# Altium schematic sheet Sheet1.SchDoc
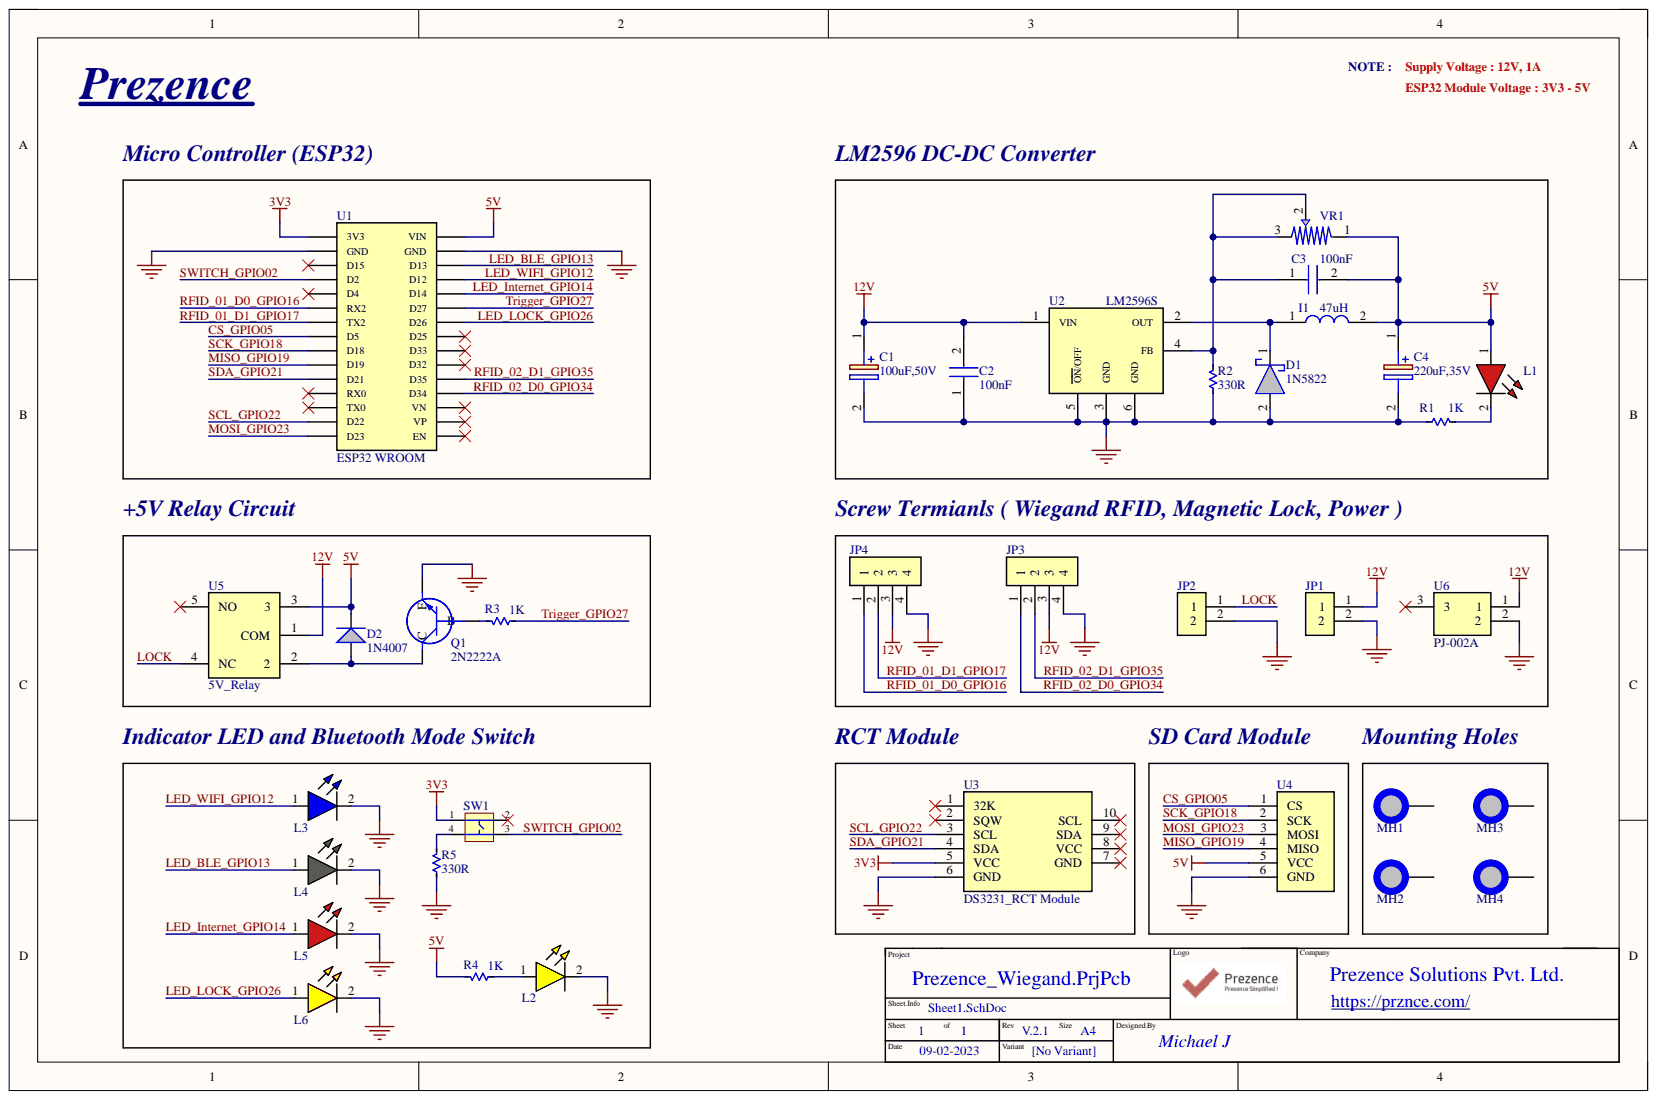
[diagram p0: Sheet1.SchDoc]

## Parts
C1  100uF,50V  [100uF,50V]
C2  100nF  [C_5.08mm]
C3  100nF  [C_5.08mm]
C4  220uF,35V  [220uF,35V]
D1  1N5822  [1N5822_(Short)]
D2  1N4007  [1N4007]
I1  47uH  [47uH_Through_Hole]
JP1  Pluggable, Receptacle, Horizontal, 5.08 Pitch, Terminal Block Connector  [2_Pin_Pluggable_Terminal]  (value not drawn)
JP2  Pluggable, Receptacle, Horizontal, 5.08 Pitch, Terminal Block Connector  [2_Pin_Pluggable_Terminal]  (value not drawn)
JP3  Screw_Terminal  [4_Pin_Pluggable_Terminal]  (value not drawn)
JP4  Screw_Terminal  [4_Pin_Pluggable_Terminal]  (value not drawn)
L1  LED  [LED_RED]  (value not drawn)
L2  LED  [LED_Yellow]  (value not drawn)
L3  LED  [LED_Blue]
L4  LED  [LED_Green]
L5  LED  [LED_RED]
L6  LED  [LED_Orange]
MH1  Mounting_Holes_3.2mm  [Mounting_Hole_3.2mm]  (value not drawn)
MH2  Mounting_Holes_3.2mm  [Mounting_Hole_3.2mm]  (value not drawn)
MH3  Mounting_Holes_3.2mm  [Mounting_Hole_3.2mm]  (value not drawn)
MH4  Mounting_Holes_3.2mm  [Mounting_Hole_3.2mm]  (value not drawn)
Q1  2N2222A  [2N2222A_(TO-92)]
R1  1K  [Resistor]
R2  330R  [Resistor]
R3  1K  [Resistor]
R4  1K  [Resistor]
R5  330R  [Resistor]
SW1  switch 12mm  [Switch_12mm]  (value not drawn)
U1  ESP32 WROOM  [ESP32_(Through Hole)]
U2  LM2596S  [LM2596]
U3  DS3231_RCT Module  [DS3231_RCT_MODULE]
U4  SD_Card_Module  [SD_Card_Module]
U5  5V_Relay  [SRD_05VDC_SL_C]
U6  PJ-002A  [PJ002A]
VR1  3296W-1-103  [3296W-1-103]  (value not drawn)

## Nets
3V3: SW1.1 U1.1(3V3) U3.5(VCC)
5V: C3.2 C4.1 D2.1 I1.2 L1.1 R4.2 U1.30(VIN) U4.5(VCC) U5.3 VR1.1
12V: C1.1 C2.2 JP1.1 JP3.3 JP4.3 U2.1(VIN) U5.1(COM) U6.1
GND: C1.2 C2.1 C4.2 D1.2 JP1.2 JP2.2 JP3.4 JP4.4 L2.2 L3.2 L4.2 L5.2 L6.2 Q1.1 R1.1 R2.1 R5.1 U1.2(GND) U1.29(GND) U2.3(GND) U2.5(ON/OFF) U2.6(GND) U3.6(GND) U4.6(GND) U6.2
CS_GPIO05: U1.8(D5) U4.1(CS)
LED_BLE_GPIO13: L4.1 U1.28(D13)
LED_Internet_GPIO14: L5.1 U1.26(D14)
LED_LOCK_GPIO26: L6.1 U1.24(D26)
LED_WIFI_GPIO12: L3.1 U1.27(D12)
LOCK: JP2.1 U5.4(NC)
MISO_GPIO19: U1.10(D19) U4.4(MISO)
MOSI_GPIO23: U1.15(D23) U4.3(MOSI)
RFID_01_D0_GPIO16: JP4.1 U1.6(RX2)
RFID_01_D1_GPIO17: JP4.2 U1.7(TX2)
RFID_02_D0_GPIO34: JP3.1 U1.19(D34)
RFID_02_D1_GPIO35: JP3.2 U1.20(D35)
SCK_GPIO18: U1.9(D18) U4.2(SCK)
SCL_GPIO22: U1.14(D22) U3.3(SCL)
SDA_GPIO21: U1.11(D21) U3.4(SDA)
SWITCH_GPIO02: SW1.3 U1.4(D2)
Trigger_GPIO27: R3.1 U1.25(D27)
NetC3_1: C3.1 R2.2 U2.4(FB) VR1.2 VR1.3
NetD1_1: D1.1 I1.1 U2.2(OUT)
NetD2_2: D2.2 Q1.3 U5.2
NetL1_2: L1.2 R1.2
NetL2_1: L2.1 R4.1
NetQ1_2: Q1.2 R3.2
NetR5_2: R5.2 SW1.4

Not connected: MH1 1; MH2 1; MH3 1; MH4 1; SW1 2; U1 3(D15) 5(D4) 12(RX0) 13(TX0) 16(EN) 17(VP) 18(VN) 21(D32) 22(D33) 23(D25); U3 1(32K) 2(SQW) 7(GND) 8(VCC) 9(SDA) 10(SCL); U5 5(NO); U6 3

## Library data of the parts
C1 = MAL203690109E3: Capacitor Polarised; Manufacturer_Name: Vishay; Arrow Part Number: MAL203690109E3; Arrow Price/Stock: https://www.arrow.com/en/products/mal203690109e3/vishay; Component Kind: Standard; Datasheet Link: https://www.vishay.com/docs/28312/036rsp.pdf; Height: 12mm; Library Name: Schlib.SchLib; Manufacturer_Part_Number: MAL203690109E3; Mouser Part Number: 594-2222-036-90109; Mouser Price/Stock: https://www.mouser.co.uk/ProductDetail/Vishay-BC-Components/MAL203690109E3?qs=4T%252B5yYZyZYdFWMOgqUZuiA%3D%3D
C2 C3 = C0805: Capacitor, C0805; Manufacturer_Name: Samsung Electro-Mechanics; Manufacturer_Part_Number: CL21B104KCFNNNE; Arrow Part Number: CL21B104KCFNNNE; Arrow Price/Stock: https://www.arrow.com/en/products/cl21b104kcfnnne/samsung-electro-mechanics; Component Kind: Standard; Datasheet Link: https://datasheet.datasheetarchive.com/originals/distributors/DKDS-19/374389.pdf; Height: 1.35mm; Library Name: Schlib.SchLib; Mouser Part Number: 187-CL21B104KCFNNNE; Mouser Price/Stock: https://www.mouser.co.uk/ProductDetail/Samsung-Electro-Mechanics/CL21B104KCFNNNE?qs=lptGheUA4EwiEGVRX9oguw%3D%3D
C4 = 220uF,35V: Capacitor Polarised,220uF,35V; Manufacturer_Name: United Chemi-Con; Manufacturer_Part_Number: ESMG350ELL221MHB5D; Arrow Part Number: ESMG350ELL221MHB5D; Arrow Price/Stock: https://www.arrow.com/en/products/esmg350ell221mhb5d/united-chemi-con; Component Kind: Standard; Datasheet Link: https://www.mouser.co.uk/datasheet/2/420/United-Chemi-Con-516303.pdf; Height: 13mm; Library Name: Schlib.SchLib; Mouser Part Number: 661-ESMG350ELL221MHB; Mouser Price/Stock: https://www.mouser.co.uk/ProductDetail/United-Chemi-Con/ESMG350ELL221MHB5D?qs=p6CSSyiVosJqUW3WNj%2Ff2A%3D%3D
D1 = 1N5822: Schottky Diode; Manufacturer_Name: NEUTRAL; Component Kind: Standard; Library Name: Schlib.SchLib; Manufacturer_Part_Number: 1N5822
D2 = 1N4007: Diode; Manufacturer_Name: Vishay; Manufacturer_Part_Number: 1N4007-E3/73; Arrow Part Number: 1N4007-E3/73; Arrow Price/Stock: https://www.arrow.com/en/products/1n4007-e373/vishay?region=asia; Component Kind: Standard; Datasheet Link: https://mouser.componentsearchengine.com/Datasheets/2/1N4007-E3_73.pdf; Library Name: Schlib.SchLib; Mouser Part Number: 625-1N4007-E3/73; Mouser Price/Stock: https://www.mouser.co.uk/ProductDetail/Vishay-General-Semiconductor/1N4007-E3-73?qs=N4vtoAxH%2FSqT3Ng3e4K0Gw%3D%3D
I1 = SMDRI127-470MT: Inductor; Manufacturer_Name: Shun Xiang Nuo Elec; Component Kind: Standard; Datasheet Link: https://datasheet.lcsc.com/szlcsc/ShunXiang-Connaught-Elec-SMDRI127-470MT_C9906.pdf; Height: 8mm; Library Name: Schlib.SchLib; Manufacturer_Part_Number: SMDRI127-470MT
JP1 JP2 = 2_Pin_Pluggable_Terminal: 2 Pin Pluggable, Receptacle, Horizontal, 5.08 Pitch, Terminal Block Connector; Component Kind: Standard; Library Name: Schlib.SchLib
JP3 JP4 = 4_Pin_Pluggable_Terminal: Pluggable, Receptacle, Horizontal, 5.08 Pitch, Terminal Block Connector; Component Kind: Standard; Library Name: Schlib.SchLib
L1 L5 = LED_Red: Light Emitting Diode, 5MM, 3.3V; Component Kind: Standard; Library Name: Schlib.SchLib
L2 = LED_Yellow: Light Emitting Diode, 5MM, 3.3V; Component Kind: Standard; Library Name: Schlib.SchLib
L3 = LED_Blue: Light Emitting Diode, 5MM, 3.3V; Component Kind: Standard; Library Name: Schlib.SchLib
L4 = LED_Green: Light Emitting Diode, 5MM, 3.3V; Component Kind: Standard; Library Name: Schlib.SchLib
L6 = LED_Orange: Light Emitting Diode, 5MM, 3.3V; Component Kind: Standard; Library Name: Schlib.SchLib
Q1 = 2N2222A: Transistor BJT NPN; Manufacturer_Name: Central Semiconductor; Component Kind: Standard; Datasheet Link: https://my.centralsemi.com/get_document.php?cmp=1&mergetype=pd&mergepath=pd&pdf_id=2N2221A.PDF; Library Name: Schlib.SchLib; Manufacturer_Part_Number: 2N2222A; Mouser Part Number: 610-2N2222A; Mouser Price/Stock: https://www.mouser.com/Search/Refine.aspx?Keyword=610-2N2222A
R1-R5 = Resistor: Component Kind: Standard; Description: Resistor; Library Name: Schlib.SchLib
SW1 = Switch_12mm: Switch Tactile N.O. SPST Flat Plunger PC Pins 0.05A 24VDC 1.27N Thru-Hole Bag; Manufacturer: Omron; Manufacturer Part Number: B3F-5001; Actuator Color: Blue; Actuator Length: 0.8mm; Actuator Type: Standard; Contact Current Rating: 50mA; Contact Resistance: 100mR; Electrical Life: 10000000Cycles; Illumination Type: Non-Illuminated,Illuminated; Max Voltage Rating (DC): 24V; Number of Poles: 1; Ratings: IP00; Switch Function: Off-Mom; Switch Travel: 0.3mm; Category: Tactile Switches; Component Kind: Standard; ComponentLink1Description: https://datasheet.ciiva.com/2901/b3f-0811-2901588.pdf?src-supplier=Digi-Key; ComponentLink1URL: https://datasheet.ciiva.com/2901/b3f-0811-2901588.pdf?src-supplier=Digi-Key; ComponentLink2Description: https://datasheet.ciiva.com/16154/en-b3f-16154917.pdf?src-supplier=Onlinecomponents.com; ComponentLink2URL: https://datasheet.ciiva.com/16154/en-b3f-16154917.pdf?src-supplier=Onlinecomponents.com; ComponentLink3Description: https://datasheet.ciiva.com/30248/en-b3f-30248566.pdf?src-supplier=Onlinecomponents.com; ComponentLink3URL: https://datasheet.ciiva.com/30248/en-b3f-30248566.pdf?src-supplier=Onlinecomponents.com; ComponentLink4Description: https://datasheet.ciiva.com/1532/611570-1532602.pdf?src-supplier=Element14; ComponentLink4URL: https://datasheet.ciiva.com/1532/611570-1532602.pdf?src-supplier=Element14; Contact Material: Gold,Silver; Contact Plating: Silver,Gold; Current Rating: 50mA; Height: 4.3mm; Lead Free: Lead Free; Length: 12mm; Library Name: Schlib.SchLib; Max Operating Temperature: 70°C; Mechanical Life: 10000000Cycles; Min Operating Temperature: -25°C; Mount: Through Hole; Operating Force: 1.27N; Orientation: Straight; Package Quantity: 500; Packaging: Bulk; Pricing 1: 1=2.75000, 10=2.58900, 25=2.35320, 100=2.03950, 250=1.96104 (USD); REACH SVHC: Unknown; RoHS: Yes; RoHS Compliant: Yes; Series: B3F; Stock 1: 393; Supplier 1: Digi-Key; Supplier Part Number 1: SW968-ND; Termination: PC Pin; Throw Configuration: SPST; Voltage Rating (DC): 24V; Weight: 0.85g; Width: 12mm
U1 = ESP32 WROOM: ESP32 WROOM, 3.3V; Component Kind: Standard; Library Name: Schlib.SchLib
U2 = LM2596S-5.0: Integrated Circuit,Step-down type TO-263-5 DC-DC Converters ROHS; Manufacturer_Name: Texas Instruments; Arrow Part Number: LM2596S-5.0; Arrow Price/Stock: https://www.arrow.com/en/products/lm2596s-5.0/texas-instruments?region=nac; Component Kind: Standard; Datasheet Link: https://www.ti.com/lit/gpn/LM2596; Library Name: Schlib.SchLib; Manufacturer_Part_Number: LM2596S-5.0; Mouser Part Number: 926-LM2596S-50; Mouser Price/Stock: https://www.mouser.co.uk/ProductDetail/Texas-Instruments/LM2596S-5.0?qs=X1J7HmVL2ZGcOr96VNr%252B%2Fw%3D%3D
U3 = RCT Module: Component Kind: Standard; Description: DS3231_RCT Module; Library Name: Schlib.SchLib
U5 = SRD-05VDC-SL-C: Relay or Contactor; Manufacturer_Name: Songle Relay; Component Kind: Standard; Datasheet Link: http://files.microjpm.webnode.com/200001010-dda41de9e1/Songle%20Relay%20Datasheet.pdf; Library Name: Schlib.SchLib; Manufacturer_Part_Number: SRD-05VDC-SL-C
U6 = PJ-002A: DC-005 DC Power Jack Female Adapter PCB Mount - 2.1 x 5.5mm; Manufacturer_Name: CUI Inc.; Arrow Part Number: PJ-002A; Arrow Price/Stock: https://www.arrow.com/en/products/pj-002a/cui-devices?region=nac; Component Kind: Standard; Datasheet Link: https://www.cui.com/product/resource/digikeypdf/pj-002a.pdf; Height: 11mm; Library Name: Schlib.SchLib; Manufacturer_Part_Number: PJ-002A; Mouser Part Number: 490-PJ-002A; Mouser Price/Stock: https://www.mouser.co.uk/ProductDetail/CUI-Devices/PJ-002A?qs=WyjlAZoYn51CKfAix9Mngw%3D%3D
VR1 = 3296W-1-103: ±10% ±250ppm/℃ 10kΩ Plugin Variable Resistors/Potentiometers ROHS; Manufacturer_Name: Chengdu Guosheng Tech; Component Kind: Standard; Datasheet Link: https://datasheet.lcsc.com/szlcsc/1811271436_Chengdu-Guosheng-Tech-3296W-1-103_C330432.pdf; Height: 10.28mm; Library Name: Schlib.SchLib; Manufacturer_Part_Number: 3296W-1-103
MH1-MH4 = Mounting_Holes_3.2mm: Component Kind: Standard; Description: Mounting_Holes_3.2mm; Library Name: Schlib.SchLib
U4 = SD_Card_Module: Component Kind: Standard; Description: SD_Card_Module; Library Name: Schlib.SchLib
